# Altium schematic sheet Main_WiFi.SchDoc(Main_WiFi)
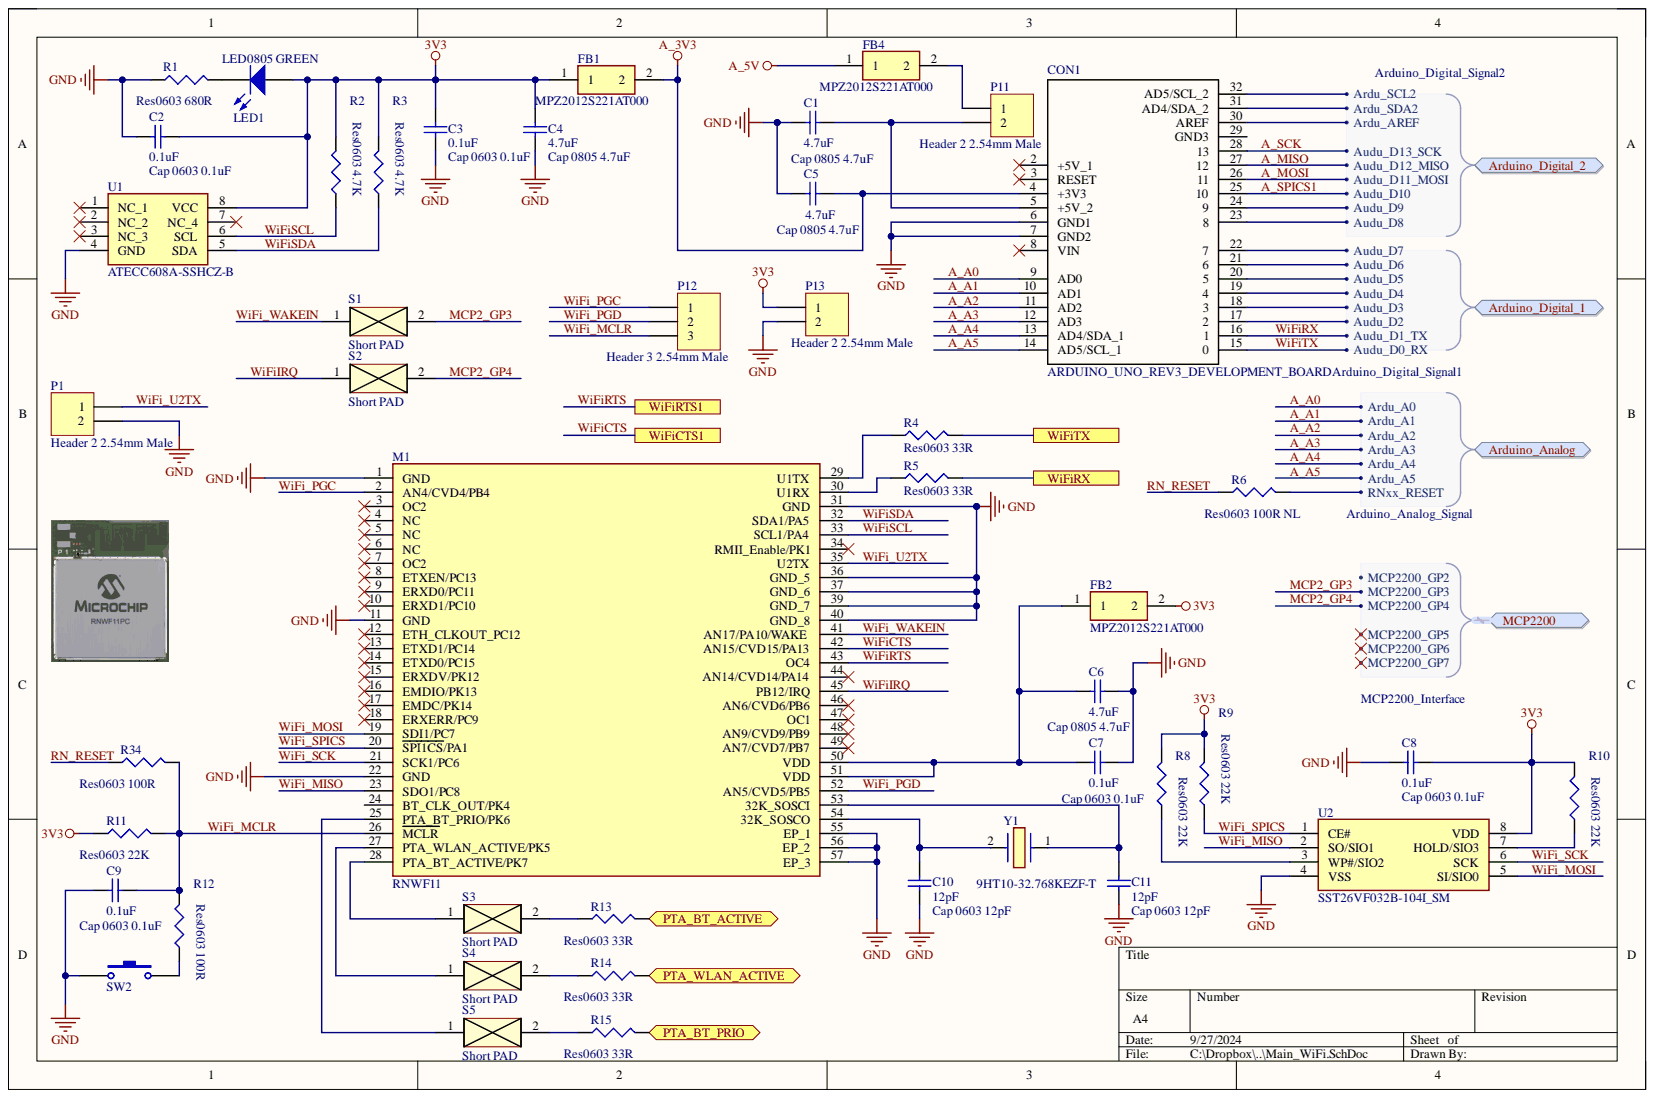
[diagram p0: Main_WiFi.SchDoc]

## Parts
C1  Cap 0805 4.7uF  value 4.7uF  [C0805]
C2  Cap 0603 0.1uF  value 0.1uF  [0603C]
C3  Cap 0603 0.1uF  value 0.1uF  [0603C]
C4  Cap 0805 4.7uF  value 4.7uF  [C0805]
C5  Cap 0805 4.7uF  value 4.7uF  [C0805]
C6  Cap 0805 4.7uF  value 4.7uF  [C0805]
C7  Cap 0603 0.1uF  value 0.1uF  [0603C]
C8  Cap 0603 0.1uF  value 0.1uF  [0603C]
C9  Cap 0603 0.1uF  value 0.1uF  [0603C]
C10  Cap 0603 12pF  value 12pF  [0603C]
C11  Cap 0603 12pF  value 12pF  [0603C]
CON1  ARDUINO_UNO_REV3_DEVELOPMENT_BOARD  [ARDUINO_UNO_REV3_SHIELD]
FB1  MPZ2012S221AT000  [BEADC2012X105N]
FB2  MPZ2012S221AT000  [BEADC2012X105N]
FB4  MPZ2012S221AT000  [BEADC2012X105N]
LED1  LED0805 GREEN  [LED0805_G]
M1  RNWF11  [WFI32E01PEI]
P1  Header 2 2.54mm Male  [HDR1X2]
P11  Header 2 2.54mm Male  [HDR1X2]
P12  Header 3 2.54mm Male  [HDR1X3]
P13  Header 2 2.54mm Male  [HDR1X2]
R1  Res0603 680R  [0603R]
R2  Res0603 4.7K  [0603R]
R3  Res0603 4.7K  [0603R]
R4  Res0603 33R  value 33R  [0603R]
R5  Res0603 33R  value 33R  [0603R]
R6  Res0603 100R NL  value 100R  NL  [0603R]
R8  Res0603 22K  value 22K  [0603R]
R9  Res0603 22K  value 22K  [0603R]
R10  Res0603 22K  value 22K  [0603R]
R11  Res0603 22K  value 22K  [0603R]
R12  Res0603 100R  value 100R  [0603R]
R13  Res0603 33R  [0603R]
R14  Res0603 33R  [0603R]
R15  Res0603 33R  [0603R]
R34  Res0603 100R  value 100R  [0603R]
S1  Short PAD  [Short_PAD]
S2  Short PAD  [Short_PAD]
S3  Short PAD  [Short_PAD]
S4  Short PAD  [Short_PAD]
S5  Short PAD  [Short_PAD]
SW2  SW-PB  [Button_SMD]  (value not drawn)
U1  ATECC608A-SSHCZ-B  [SOIC127P600X175-8N]
U2  SST26VF032B-104I_SM  [SOIC127P794X203-8N]
Y1  9HT10-32.768KEZF-T  [9HT1032768KEZFT]

## Ports
Arduino_Analog, Arduino_Analog.Ardu_A0, Arduino_Analog.Ardu_A1, Arduino_Analog.Ardu_A2, Arduino_Analog.Ardu_A3, Arduino_Analog.Ardu_A4, Arduino_Analog.Ardu_A5, Arduino_Analog.RNxx_RESET, Arduino_Digital_1, Arduino_Digital_1.Audu_D0_RX, Arduino_Digital_1.Audu_D1_TX, Arduino_Digital_1.Audu_D2, Arduino_Digital_1.Audu_D3, Arduino_Digital_1.Audu_D4, Arduino_Digital_1.Audu_D5, Arduino_Digital_1.Audu_D6, Arduino_Digital_1.Audu_D7, Arduino_Digital_2, Arduino_Digital_2.Ardu_AREF, Arduino_Digital_2.Ardu_SCL2, Arduino_Digital_2.Ardu_SDA2, Arduino_Digital_2.Audu_D8, Arduino_Digital_2.Audu_D9, Arduino_Digital_2.Audu_D10, Arduino_Digital_2.Audu_D11_MOSI, Arduino_Digital_2.Audu_D12_MISO, Arduino_Digital_2.Audu_D13_SCK, MCP2200, MCP2200.MCP2200_GP2, MCP2200.MCP2200_GP3, MCP2200.MCP2200_GP4, MCP2200.MCP2200_GP5, MCP2200.MCP2200_GP6, MCP2200.MCP2200_GP7, PTA_BT_ACTIVE, PTA_BT_PRIO, PTA_WLAN_ACTIVE, WiFiCTS1, WiFiRTS1, WiFiRX, WiFiTX

## Nets
3V3: C2.2 C3.2 C4.2 C8.2 FB1.1 FB2.2 LED1.1 P13.1 R2.2 R3.2 R8.2 R9.2 R10.2 R11.1 U1.8(VCC) U2.8(VDD)
A_3V3: C5.2 CON1.4(+3V3) FB1.2
A_5V: FB4.1
GND: C1.1 C2.1 C3.1 C4.1 C5.1 C6.1 C7.2 C8.1 C9.1 C10.1 C11.1 CON1.6(GND1) CON1.7(GND2) M1.1(GND) M1.11(GND) M1.22(GND) M1.31(GND) M1.36(GND_5) M1.37(GND_6) M1.39(GND_7) M1.40(GND_8) M1.55(EP_1) M1.56(EP_2) M1.57(EP_3) P1.2 P13.2 R1.1 SW2.1 U1.4(GND) U2.4(VSS)
A_A0: CON1.9(AD0) ; port Arduino_Analog
A_A1: CON1.10(AD1) ; port Arduino_Analog
A_A2: CON1.11(AD2) ; port Arduino_Analog
A_A3: CON1.12(AD3) ; port Arduino_Analog
A_A4: CON1.13(AD4/SDA_1) ; port Arduino_Analog
A_A5: CON1.14(AD5/SCL_1) ; port Arduino_Analog
A_MISO: CON1.27 ; port Arduino_Digital_2
A_MOSI: CON1.26 ; port Arduino_Digital_2
A_SCK: CON1.28 ; port Arduino_Digital_2
A_SPICS1: CON1.25 ; port Arduino_Digital_2
Arduino_Analog: R6.2 ; port Arduino_Analog
MCP2_GP3: S1.2 ; port MCP2200
MCP2_GP4: S2.2 ; port MCP2200
PTA_BT_ACTIVE: R13.2 ; port PTA_BT_ACTIVE
PTA_BT_PRIO: R15.2 ; port PTA_BT_PRIO
PTA_WLAN_ACTIVE: R14.2 ; port PTA_WLAN_ACTIVE
RN_RESET: R6.1 R34.1
WiFi_MCLR: C9.2 M1.26(MCLR) P12.3 R11.2 R12.2 R34.2
WiFi_MISO: M1.23(SDO1/PC8) U2.2(SO/SIO1)
WiFi_MOSI: M1.19(SDI1/PC7) U2.5(SI/SIO0)
WiFi_PGC: M1.2(AN4/CVD4/PB4) P12.1
WiFi_PGD: M1.52(AN5/CVD5/PB5) P12.2
WiFi_SCK: M1.21(SCK1/PC6) U2.6(SCK)
WiFi_SPICS: M1.20(SPI1CS/PA1) R9.1 U2.1(CE#)
WiFi_U2TX: M1.35(U2TX) P1.1
WiFi_WAKEIN: M1.41(AN17/PA10/WAKE) S1.1
WiFiCTS: M1.42(AN15/CVD15/PA13) ; port WiFiCTS1
WiFiIRQ: M1.45(PB12/IRQ) S2.1
WiFiRTS: M1.43(OC4) ; port WiFiRTS1
WiFiRX: CON1.16 ; port Arduino_Digital_1
WiFiSCL: M1.33(SCL1/PA4) R2.1 U1.6(SCL)
WiFiSDA: M1.32(SDA1/PA5) R3.1 U1.5(SDA)
WiFiTX: CON1.15 ; port Arduino_Digital_1
NetC1_2: C1.2 CON1.5(+5V_2) P11.2
NetC6_2: C6.2 C7.1 FB2.1 M1.50(VDD) M1.51(VDD)
NetC10_2: C10.2 M1.54(32K_SOSCO) Y1.2
NetC11_2: C11.2 M1.53(32K_SOSCI) Y1.1
NetCON1_17: CON1.17 ; port Arduino_Digital_1
NetCON1_18: CON1.18 ; port Arduino_Digital_1
NetCON1_19: CON1.19 ; port Arduino_Digital_1
NetCON1_20: CON1.20 ; port Arduino_Digital_1
NetCON1_21: CON1.21 ; port Arduino_Digital_1
NetCON1_22: CON1.22 ; port Arduino_Digital_1
NetCON1_23: CON1.23 ; port Arduino_Digital_2
NetCON1_24: CON1.24 ; port Arduino_Digital_2
NetCON1_30: CON1.30(AREF) ; port Arduino_Digital_2
NetCON1_31: CON1.31(AD4/SDA_2) ; port Arduino_Digital_2
NetCON1_32: CON1.32(AD5/SCL_2) ; port Arduino_Digital_2
NetFB4_2: FB4.2 P11.1
NetLED1_2: LED1.2 R1.2
NetM1_25: M1.25(PTA_BT_PRIO/PK6) S5.1
NetM1_27: M1.27(PTA_WLAN_ACTIVE/PK5) S4.1
NetM1_28: M1.28(PTA_BT_ACTIVE/PK7) S3.1
NetM1_29: M1.29(U1TX) R4.1
NetM1_30: M1.30(U1RX) R5.1
NetR4_2: R4.2 ; port WiFiTX
NetR5_2: R5.2 ; port WiFiRX
NetR8_1: R8.1 U2.3(WP#/SIO2)
NetR10_1: R10.1 U2.7(HOLD/SIO3)
NetR12_1: R12.1 SW2.2
NetR13_1: R13.1 S3.2
NetR14_1: R14.1 S4.2
NetR15_1: R15.1 S5.2
N00001: ; port MCP2200
N00010: ; port MCP2200
N00013: ; port MCP2200
N00016: ; port MCP2200

Not connected: CON1 2(+5V_1) 3(RESET) 8(VIN) 29(GND3); M1 3(OC2) 4(NC) 5(NC) 6(NC) 7(OC2) 8(ETXEN/PC13) 9(ERXD0/PC11) 10(ERXD1/PC10) 12(ETH_CLKOUT_PC12) 13(ETXD1/PC14) 14(ETXD0/PC15) 15(ERXDV/PK12) 16(EMDIO/PK13) 17(EMDC/PK14) 18(ERXERR/PC9) 24(BT_CLK_OUT/PK4) 34(RMII_Enable/PK1) 44(AN14/CVD14/PA14) 46(AN6/CVD6/PB6) 47(OC1) 48(AN9/CVD9/PB9) 49(AN7/CVD7/PB7); U1 1(NC_1) 2(NC_2) 3(NC_3) 7(NC_4)

## Library data of the parts
C1 C4-C6 = Cap 0805: Capacitor (Semiconductor SIM Model); Component Kind: Standard; LatestRevisionDate: 29-May-2009; LatestRevisionNote: IPC-7351 Footprint Added.; Library Name: MCHP_Parts_2016.SchLib; PackageDescription: Chip Capacitor; PackageReference: C1206; Published: 8-Jun-2000; Publisher: Altium Limited
C2 C3 C7-C11 = Cap 0603: Capacitor (Semiconductor SIM Model); Component Kind: Standard; LatestRevisionDate: 29-May-2009; LatestRevisionNote: IPC-7351 Footprint Added.; Library Name: MCHP_Parts_2016.SchLib; PackageDescription: Chip Capacitor; PackageReference: C0603; Published: 8-Jun-2000; Publisher: Altium Limited
CON1 = ARDUINO_UNO_REV3_BASE: MP: ARDUINO UNO REV3 DEVELOPMENT BOARD; Availability: Unavailable; Component Kind: Standard; Library Name: MCHP_Parts_2016.SchLib; MF: Arduino; Package: None; Price: None; SnapEDA_Link: https://www.snapeda.com/parts/ARDUINO%20UNO%20REV3%20DEVELOPMENT%20BOARD/Arduino/view-part/?ref=snap
FB1 FB2 FB4 = MPZ2012S221AT000: Ferrite Bead; Manufacturer_Name: TDK; Arrow Part Number: MPZ2012S221AT000; Arrow Price/Stock: https://www.arrow.com/en/products/mpz2012s221at000/tdk?region=nac; Component Kind: Standard; Datasheet Link: https://product.tdk.com/system/files/dam/doc/product/emc/emc/beads/catalog/beads_commercial_power_mpz2012_en.pdf; Height: 1.05mm; Library Name: SamacSys.SchLib; Manufacturer_Part_Number: MPZ2012S221AT000; Mouser Part Number: 810-MPZ2012S221AT000; Mouser Price/Stock: https://www.mouser.co.uk/ProductDetail/TDK/MPZ2012S221AT000?qs=pLY5GE0xrmJYuLs3YjLRbg%3D%3D
LED1 = LED0805 GREEN: Typical BLUE SiC LED; Component Kind: Standard; DatasheetVersion: 16-Apr-2002; LatestRevisionDate: 17-Dec-2003; LatestRevisionNote: PCB Footprint replaced.; Library Name: MCHP_Parts_2016.SchLib; PackageDescription: SM LED; 2 C-Bend Leads; Body 3.5 x 2.8 x 1.9 mm, inc leads (LxWxH typ); PackageReference: 3.5X2.8X1.9; PackageVersion: 16-Apr-2002; Published: 8-Jun-2000; Publisher: Altium Limited
M1 = RNWF11: Integrated Circuit; Manufacturer_Name: Microchip; Manufacturer_Part_Number: WFI32E01PE-I; Arrow Part Number: WFI32E01PE-I; Arrow Price/Stock: https://www.arrow.com/en/products/wfi32e01pe-i/microchip-technology?region=nac; Component Kind: Standard; Datasheet Link: https://ww1.microchip.com/downloads/aemDocuments/documents/WSG/ProductDocuments/DataSheets/PIC32MZ1025W104-MCU-and-WFI32E01-Module-with-Wi-Fi-and-Hardware-based-Security-Accelerator-Data-Sheet-DS70005425.pdf; Height: 2.6mm; Library Name: SamacSys.SchLib; Mouser Part Number: 579-WFI32E01PE-I; Mouser Price/Stock: https://www.mouser.co.uk/ProductDetail/Microchip-Technology-Atmel/WFI32E01PE-I?qs=W%2FMpXkg%252BdQ6FpmXU%2Fd7PKw%3D%3D
P1 P11 P13 = Header 2: Header, 2-Pin; Component Kind: Standard; LatestRevisionDate: 17-Jul-2002; LatestRevisionNote: Re-released for DXP Platform.; Library Name: MCHP_Parts_2016.SchLib; Publisher: Altium Limited
P12 = Header 3: Header, 3-Pin; Component Kind: Standard; LatestRevisionDate: 17-Jul-2002; LatestRevisionNote: Re-released for DXP Platform.; Library Name: MCHP_Parts_2016.SchLib; Publisher: Altium Limited
R1 = Res0603: Resistor; Value: 680; Component Kind: Standard; LatestRevisionDate: 29-May-2009; LatestRevisionNote: IPC-7351 Footprint Added.; Library Name: MCHP_Parts_2016.SchLib; PackageDescription: Chip Resistor; PackageReference: J1-0603; Published: 8-Jun-2000; Publisher: Altium Limited
R2 R3 = Res0603: Resistor; Value: 4K7; Component Kind: Standard; LatestRevisionDate: 29-May-2009; LatestRevisionNote: IPC-7351 Footprint Added.; Library Name: MCHP_Parts_2016.SchLib; PackageDescription: Chip Resistor; PackageReference: J1-0603; Published: 8-Jun-2000; Publisher: Altium Limited
R4-R6 R8-R12 R34 = Res0603: Resistor; Component Kind: Standard; LatestRevisionDate: 29-May-2009; LatestRevisionNote: IPC-7351 Footprint Added.; Library Name: MCHP_Parts_2016.SchLib; PackageDescription: Chip Resistor; PackageReference: J1-0603; Published: 8-Jun-2000; Publisher: Altium Limited
R13-R15 = Res0603: Resistor; Value: 33R NL; Component Kind: Standard; LatestRevisionDate: 29-May-2009; LatestRevisionNote: IPC-7351 Footprint Added.; Library Name: MCHP_Parts_2016.SchLib; PackageDescription: Chip Resistor; PackageReference: J1-0603; Published: 8-Jun-2000; Publisher: Altium Limited
S1-S5 = Short_Pads: Component Kind: Standard; Library Name: MCHP_Parts_2016.SchLib
SW2 = SW-PB: Switch; Component Kind: Standard; LatestRevisionDate: 17-Jul-2002; LatestRevisionNote: Re-released for DXP Platform.; Library Name: MCHP_Parts_2016.SchLib; PackageDescription: Switch; 2 Leads; PackageReference: SPST-2; Published: 24-Mar-1999; Publisher: Altium Limited
U1 = ATECC608A-SSHCZ-B: Integrated Circuit; Manufacturer_Name: Microchip; Arrow Part Number: ATECC608A-SSHCZ-B; Arrow Price/Stock: https://www.arrow.com/en/products/atecc608a-sshcz-b/microchip-technology?region=nac; Component Kind: Standard; Datasheet Link: https://componentsearchengine.com/Datasheets/5/ATECC608A-SSHCZ-B.pdf; Height: 1.75mm; Manufacturer_Part_Number: ATECC608A-SSHCZ-B; Mouser Part Number: 556-ATECC608ASSHCZB; Mouser Price/Stock: https://www.mouser.co.uk/ProductDetail/Microchip-Technology/ATECC608A-SSHCZ-B?qs=wd5RIQLrsJjrkOhzvAyhTQ%3D%3D
U2 = SST26VF032B-104I_SM: Integrated Circuit; Manufacturer_Name: Microchip; Manufacturer_Part_Number: SST26VF032B-104I/SM; Arrow Part Number: SST26VF032B-104I/SM; Arrow Price/Stock: https://www.arrow.com/en/products/sst26vf032b-104ism/microchip-technology?region=nac; Component Kind: Standard; Datasheet Link: https://componentsearchengine.com/Datasheets/2/SST26VF032B-104I_SM.pdf; Height: 2.03mm; Mouser Part Number: 579-ST26VF032B104ISM; Mouser Price/Stock: https://www.mouser.co.uk/ProductDetail/Microchip-Technology/SST26VF032B-104I-SM?qs=wzzOUr4NhYqGG3%252BchouPkg%3D%3D
Y1 = 9HT10-32.768KEZF-T: Crystal or Oscillator; Manufacturer_Name: TXC CORPORATION; Arrow Part Number: 9HT10-32.768KEZF-T; Arrow Price/Stock: https://www.arrow.com/en/products/9ht10-32.768kezf-t/txc-corporation?region=europe; Component Kind: Standard; Datasheet Link: https://componentsearchengine.com/Datasheets/2/9HT10-32.768KEZF-T.pdf; Height: 0.85mm; Library Name: SamacSys.SchLib; Manufacturer_Part_Number: 9HT10-32.768KEZF-T; Mouser Part Number: 717-9HT10-32.768KEZF; Mouser Price/Stock: https://www.mouser.co.uk/ProductDetail/TXC-Corporation/9HT10-32.768KEZF-T?qs=2ISWkumneuHGn5PDpP%2FWxQ%3D%3D
